# Altium schematic sheet overview.SchDoc
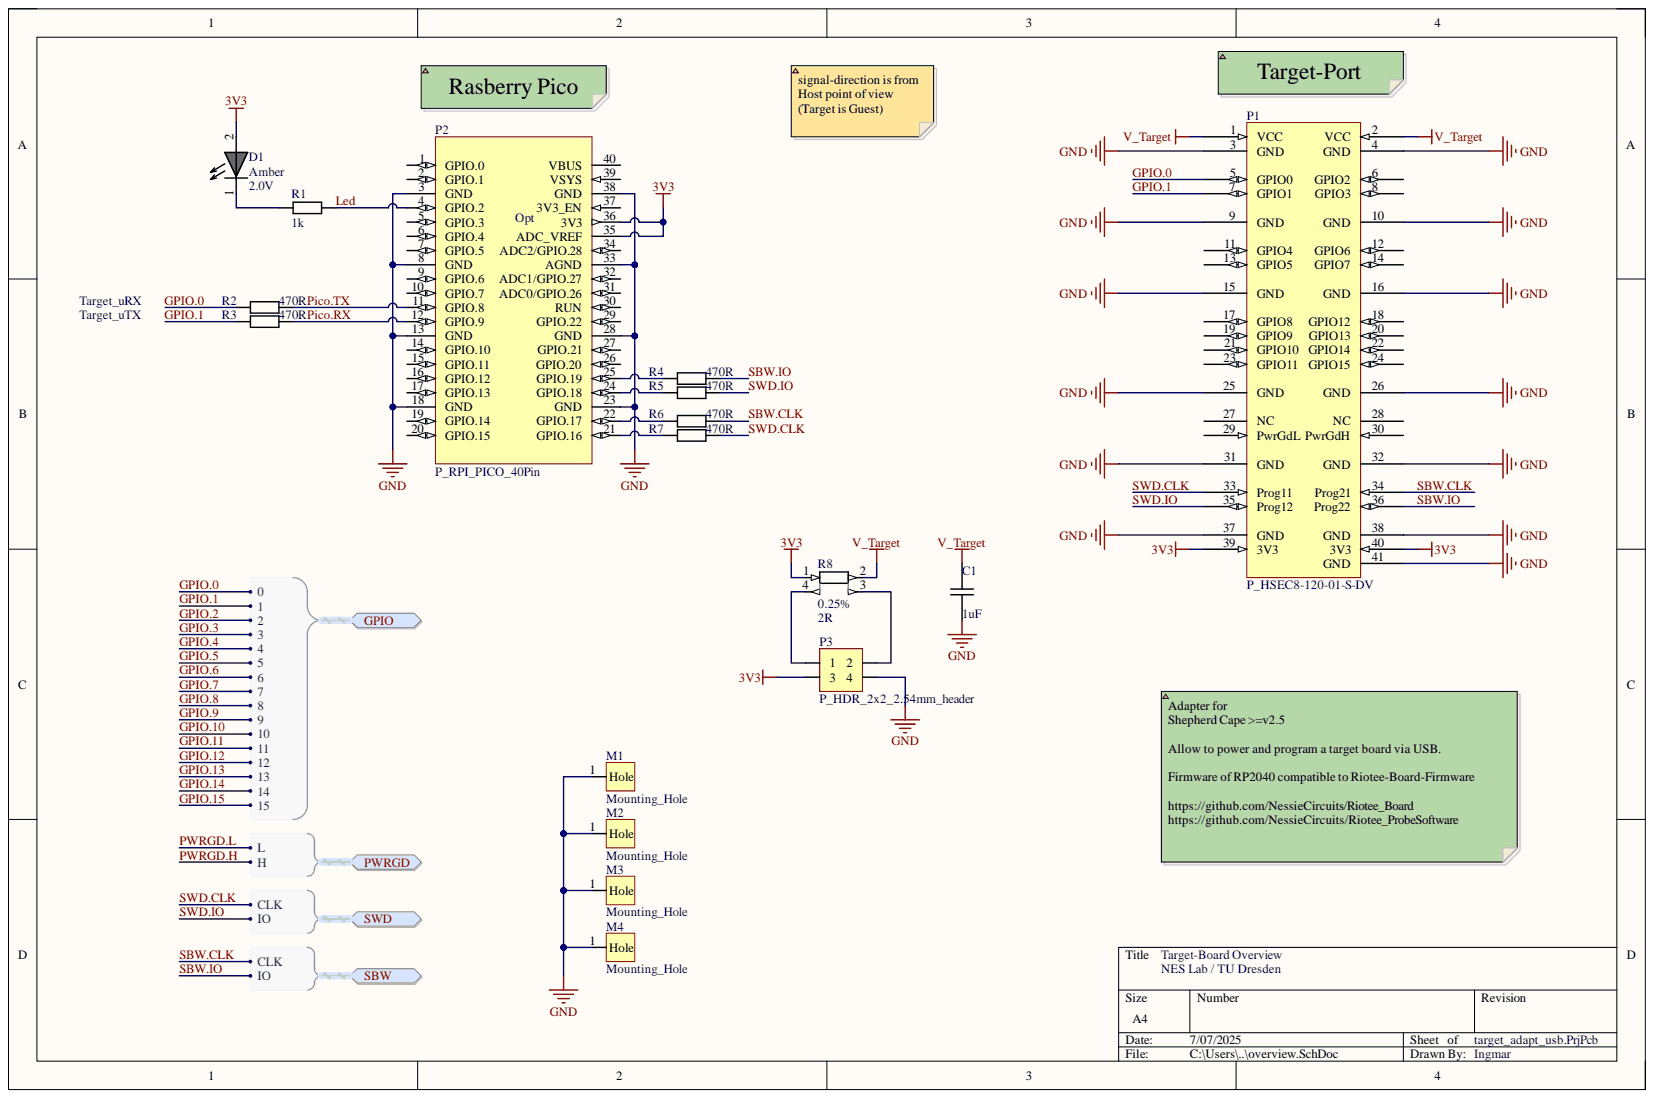
[diagram p0: overview.SchDoc]

## Parts
C1  value 1uF  [CAPC1005X06]  (hidden comment: C_0402_1uF_10V_X7R)
D1  value Amber  V_max: 2.0V  [DIOM1608X02N Orange]  (hidden comment: D_LED_0603_Amber)
M1  Mounting_Hole  [M_Mountinghole_3.2mm_min]  (no BOM)
M2  Mounting_Hole  [M_Mountinghole_3.2mm_min]  (no BOM)
M3  Mounting_Hole  [M_Mountinghole_3.2mm_min]  (no BOM)
M4  Mounting_Hole  [M_Mountinghole_3.2mm_min]  (no BOM)
P1  P_HSEC8-120-01-S-DV  [P_HSEC8-120-01-S-DV]
P2  P_RPI_PICO_40Pin  [P_rpi_pico_R2.54mm]
P3  P_HDR_2x2_2.54mm_header  [P_Pinheader_2x2_R2.54mm]
R1  value 1k  [RESC1005X04]  (hidden comment: R_0402_1k)
R2  value 470R  [RESC1005X04]  (hidden comment: R_0402_470R)
R3  value 470R  [RESC1005X04]  (hidden comment: R_0402_470R)
R4  value 470R  [RESC1005X04]  (hidden comment: R_0402_470R)
R5  value 470R  [RESC1005X04]  (hidden comment: R_0402_470R)
R6  value 470R  [RESC1005X04]  (hidden comment: R_0402_470R)
R7  value 470R  [RESC1005X04]  (hidden comment: R_0402_470R)
R8  value 2R  Tolerance: 0.25%  [RESC3116X06 Shunt]  (hidden comment: R_1206_2R_0.25%)

## Ports
GPIO, GPIO.0, GPIO.1, GPIO.2, GPIO.3, GPIO.4, GPIO.5, GPIO.6, GPIO.7, GPIO.8, GPIO.9, GPIO.10, GPIO.11, GPIO.12, GPIO.13, GPIO.14, GPIO.15, PWRGD, PWRGD.H, PWRGD.L, SBW, SBW.CLK, SBW.IO, SWD, SWD.CLK, SWD.IO

## Nets
3V3: D1.2 P1.39(3V3) P1.40(3V3) P2.35(ADC_VREF) P2.36(3V3) P3.3 R8.1
GND: C1.1 M1.1 M2.1 M3.1 M4.1 P1.3(GND) P1.4(GND) P1.9(GND) P1.10(GND) P1.15(GND) P1.16(GND) P1.25(GND) P1.26(GND) P1.31(GND) P1.32(GND) P1.37(GND) P1.38(GND) P1.41(GND) P2.3(GND) P2.8(GND) P2.13(GND) P2.18(GND) P2.23(GND) P2.28(GND) P2.33(AGND) P2.38(GND) P3.4
V_Target: C1.2 P1.1(VCC) P1.2(VCC) R8.2
GPIO.0: P1.5(GPIO0) R2.1 ; port GPIO
GPIO.1: P1.7(GPIO1) R3.1 ; port GPIO  [also labelled GPIO.10]
Led: P2.4(GPIO.2) R1.1
Pico.RX: P2.12(GPIO.9) R3.2
Pico.TX: P2.11(GPIO.8) R2.2
SBW.CLK: P1.34(Prog21) R6.2 ; port SBW
SBW.IO: P1.36(Prog22) R4.2 ; port SBW
SWD.CLK: P1.33(Prog11) R7.2 ; port SWD
SWD.IO: P1.35(Prog12) R5.2 ; port SWD
NetD1_1: D1.1 R1.2
NetP2_21: P2.21(GPIO.16) R7.1
NetP2_22: P2.22(GPIO.17) R6.1
NetP2_24: P2.24(GPIO.18) R5.1
NetP2_25: P2.25(GPIO.19) R4.1
NetP3_1: P3.1 R8.4
NetP3_2: P3.2 R8.3
GPIO.2: ; port GPIO
GPIO.3: ; port GPIO
GPIO.4: ; port GPIO
GPIO.5: ; port GPIO
GPIO.6: ; port GPIO
GPIO.7: ; port GPIO
GPIO.8: ; port GPIO
GPIO.9: ; port GPIO
GPIO.11: ; port GPIO
GPIO.12: ; port GPIO
GPIO.13: ; port GPIO
GPIO.14: ; port GPIO
GPIO.15: ; port GPIO
PWRGD.H: ; port PWRGD
PWRGD.L: ; port PWRGD

Not connected: P1 6(GPIO2) 8(GPIO3) 11(GPIO4) 12(GPIO6) 13(GPIO5) 14(GPIO7) 17(GPIO8) 18(GPIO12) 19(GPIO9) 20(GPIO13) 21(GPIO10) 22(GPIO14) 23(GPIO11) 24(GPIO15) 27(NC) 28(NC) 29(PwrGdL) 30(PwrGdH); P2 1(GPIO.0) 2(GPIO.1) 5(GPIO.3) 6(GPIO.4) 7(GPIO.5) 9(GPIO.6) 10(GPIO.7) 14(GPIO.10) 15(GPIO.11) 16(GPIO.12) 17(GPIO.13) 19(GPIO.14) 20(GPIO.15) 26(GPIO.20) 27(GPIO.21) 29(GPIO.22) 30(RUN) 31(ADC0/GPIO.26) 32(ADC1/GPIO.27) 34(ADC2/GPIO.28) 37(3V3_EN) 39(VSYS) 40(VBUS)

## Library data of the parts
C1 = .C_0402_1uF_X7R_10V: Capacitor; Manufacturer: Murata; Manufacturer PN: GRM155C71A105KE11D; Dielectric: X7R; Tolerance: 10%; V_max: 10V; Component Kind: Standard; Distributer: Mouser; Distributer PN: 81-GRM155C71A105KE1D; Price n10 [€]: 0.036; Library Name: shepherd_parts.SchLib
D1 = .D_LED_0603_Amber: LED Amber 610nm, 0603, 130 mcd, 2V@20mA; Manufacturer: Wuerth; Manufacturer PN: 150060AS75000; I_max: 20mA; I_typ: 5mA; V_typ: 1.9V; Component Kind: Standard; Distributer: Mouser; Distributer PN: 710-150060AS75000; Price n10 [€]: 0.12; Library Name: shepherd_parts.SchLib
P1 = .P_HSEC8-120-01-S-DV: Gold, Vertical, EdgeConnector, 2 Row, .8mm distance; Manufacturer: Samtec; Manufacturer PN: HSEC8-120-01-L-DV-A-K-TR; I_max: 2.8A; V_max: 240V; Component Kind: Standard; Distributer: Mouser; Distributer PN: 200-HSEC812001LDVAKT; Price n10 [€]: 3.17; Library Name: shepherd_parts.SchLib
P2 = .P_RPI_PICO_40Pin: 1V8 to 5V5; Manufacturer: Raspberry Pi; Manufacturer PN: SC0915; Component Kind: Standard; Distributer: Mouser; Distributer PN: 358-SC0915; Price n10 [€]: 3,72; V_max: 5V5; Library Name: shepherd_parts.SchLib
P3 = .P_HDR_2x2_2.54mm_male: Gold, Straight, 5.80 mm Mating, 3.46 mm termination; Manufacturer: Amphenol; Manufacturer PN: 861400042YO2319LF; I_max: 3A; V_max: 1kV; Component Kind: Standard; Distributer: Mouser; Distributer PN: 649-86140042YO2319LF; Price n10 [€]: 0.12; Library Name: shepherd_parts.SchLib
R1 = .R_0402_1k: Resistor; Manufacturer: Panasonic; Manufacturer PN: ERJ-2GEJ102X; P_max: 100mW; Tolerance: 5%; V_max: 50V; Component Kind: Standard; Distributer: Mouser; Distributer PN: 667-ERJ-2GEJ102X; Price n10 [€]: 0.02; Library Name: shepherd_parts.SchLib
R2-R7 = .R_0402_470R_1%: Resistor; Manufacturer: Panasonic; Manufacturer PN: ERJ-2RKF4700X; P_max: 100mW; Tolerance: 1%; V_max: 50V; Component Kind: Standard; Distributer: Mouser; Distributer PN: 667-ERJ-2RKF4700X; Price n10 [€]: 0.028; Library Name: shepherd_parts.SchLib
R8 = .R_1206_2R_0.25%: Resistor 25 ppm/C; Manufacturer: Yageo; Manufacturer PN: RT1206CRD072RL; P_max: 250mW; V_max: 200V; Value: 2R; Component Kind: Standard; Distributer: Mouser; Distributer PN: 603-RT1206CRD072RL; Price n10 [€]: 0.35; Library Name: shepherd_parts.SchLib
M1-M4 = Mounting_Hole: Library Name: shepherd_parts.SchLib
